# Altium schematic sheet cpuledout.SchDoc(cpuledout)
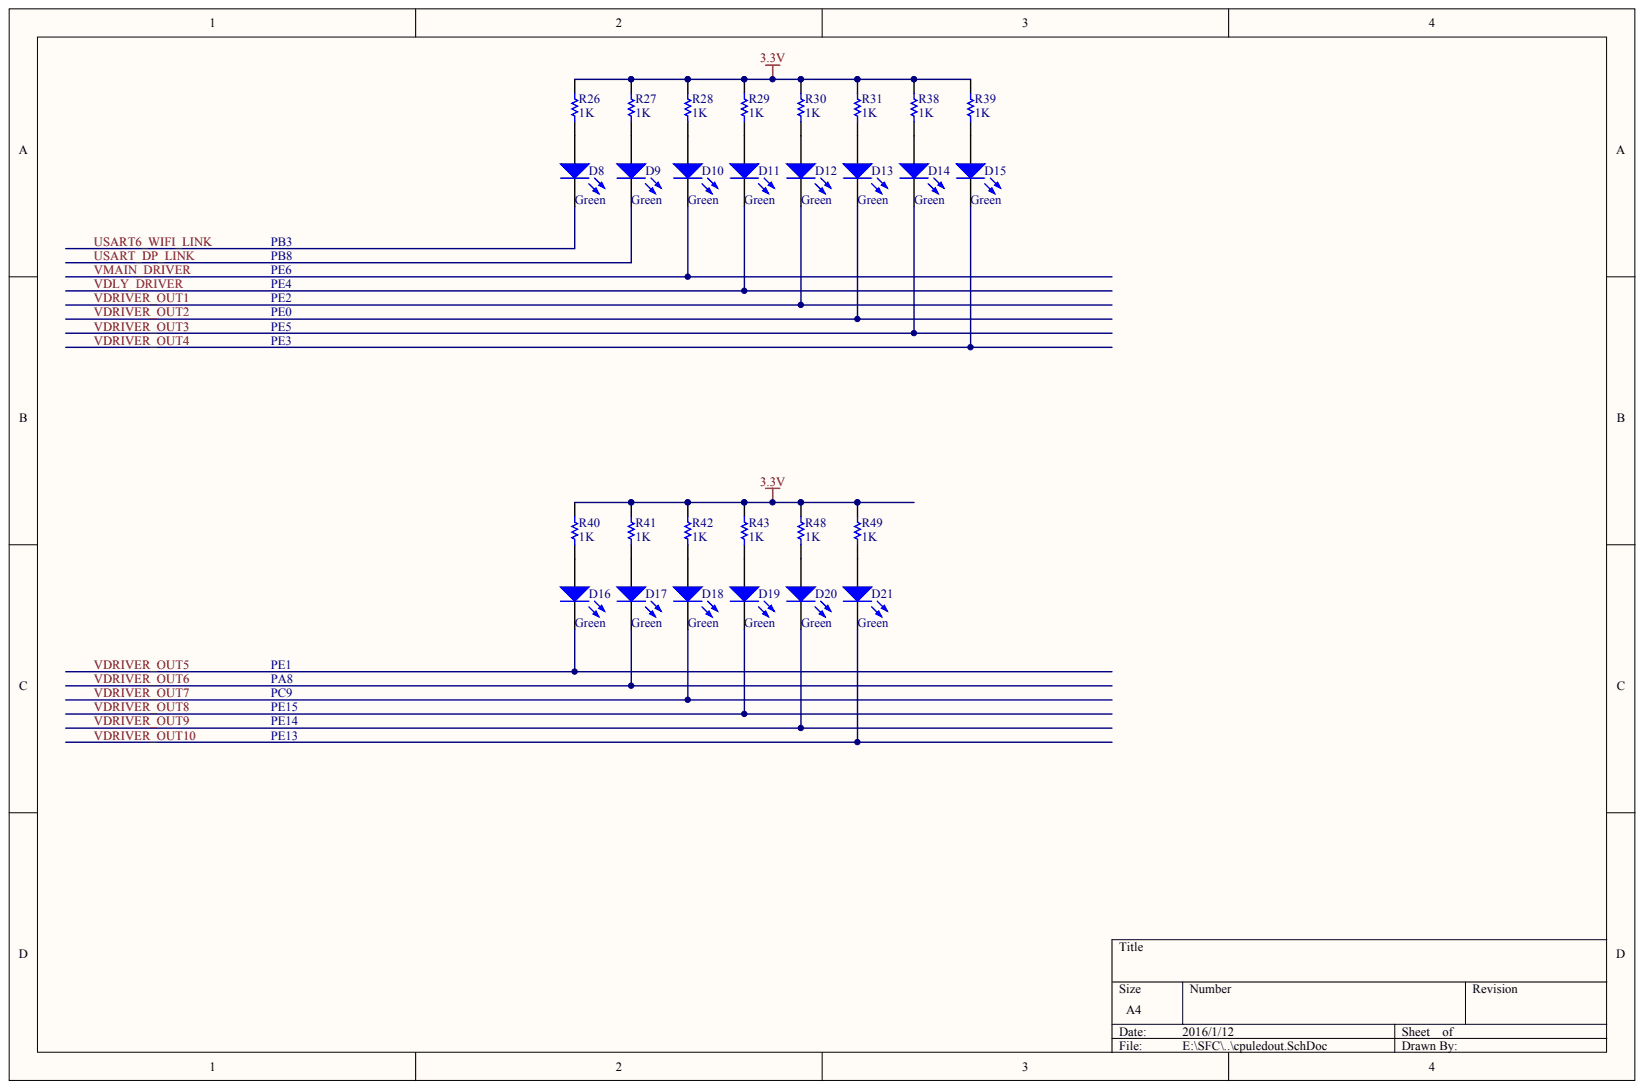
[diagram p0: cpuledout.SchDoc]

## Parts
D8  Green
D9  Green
D10  Green
D11  Green
D12  Green
D13  Green
D14  Green
D15  Green
D16  Green
D17  Green
D18  Green
D19  Green
D20  Green
D21  Green
R26  1K
R27  1K
R28  1K
R29  1K
R30  1K
R31  1K
R38  1K
R39  1K
R40  1K
R41  1K
R42  1K
R43  1K
R48  1K
R49  1K

## Nets
3.3V: R26.1 R27.1 R28.1 R29.1 R30.1 R31.1 R38.1 R39.1 R40.1 R41.1 R42.1 R43.1 R48.1 R49.1
USART6_WIFI_LINK: D8.2
USART_DP_LINK: D9.2
VDLY_DRIVER: D11.2
VDRIVER_OUT1: D12.2
VDRIVER_OUT2: D13.2
VDRIVER_OUT3: D14.2
VDRIVER_OUT4: D15.2
VDRIVER_OUT5: D16.2
VDRIVER_OUT6: D17.2
VDRIVER_OUT7: D18.2
VDRIVER_OUT8: D19.2
VDRIVER_OUT9: D20.2
VDRIVER_OUT10: D21.2
VMAIN_DRIVER: D10.2
NetD8_1: D8.1 R26.2
NetD9_1: D9.1 R27.2
NetD10_1: D10.1 R28.2
NetD11_1: D11.1 R29.2
NetD12_1: D12.1 R30.2
NetD13_1: D13.1 R31.2
NetD14_1: D14.1 R38.2
NetD15_1: D15.1 R39.2
NetD16_1: D16.1 R40.2
NetD17_1: D17.1 R41.2
NetD18_1: D18.1 R42.2
NetD19_1: D19.1 R43.2
NetD20_1: D20.1 R48.2
NetD21_1: D21.1 R49.2

## Library data of the parts
R26-R31 R38-R43 R48 R49 = (no symbol name): Value: 10K; Published: 8-Jun-2000; LatestRevisionDate: 17-Jul-2002; LatestRevisionNote: Re-released for DXP Platform.; PackageReference: AXIAL-0.3; Publisher: Altium Limited
D8-D21 = (no symbol name): Published: 8-Jun-2000; LatestRevisionDate: 08-Jul-2005; LatestRevisionNote: Mech Layer 1 removed.; PackageReference: LED-1; Publisher: Altium Limited
